# Altium schematic sheet MTIBv5_Top.SchDoc
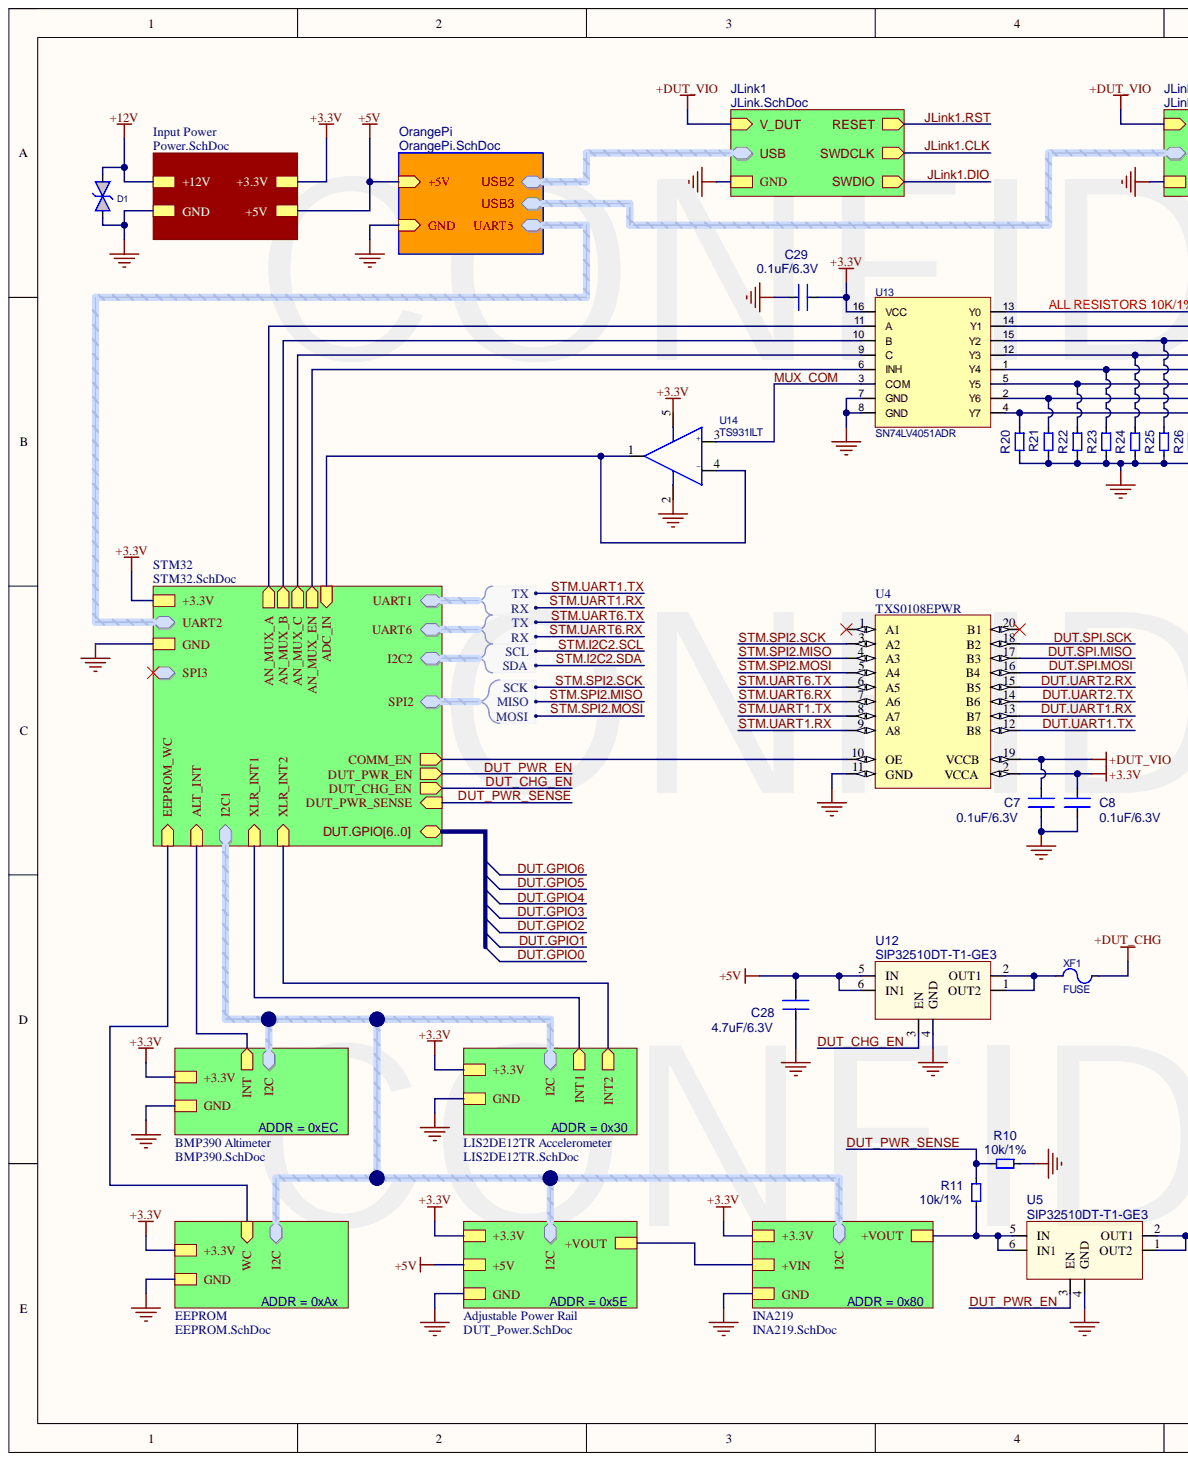
[diagram p0: MTIBv5_Top.SchDoc, left]
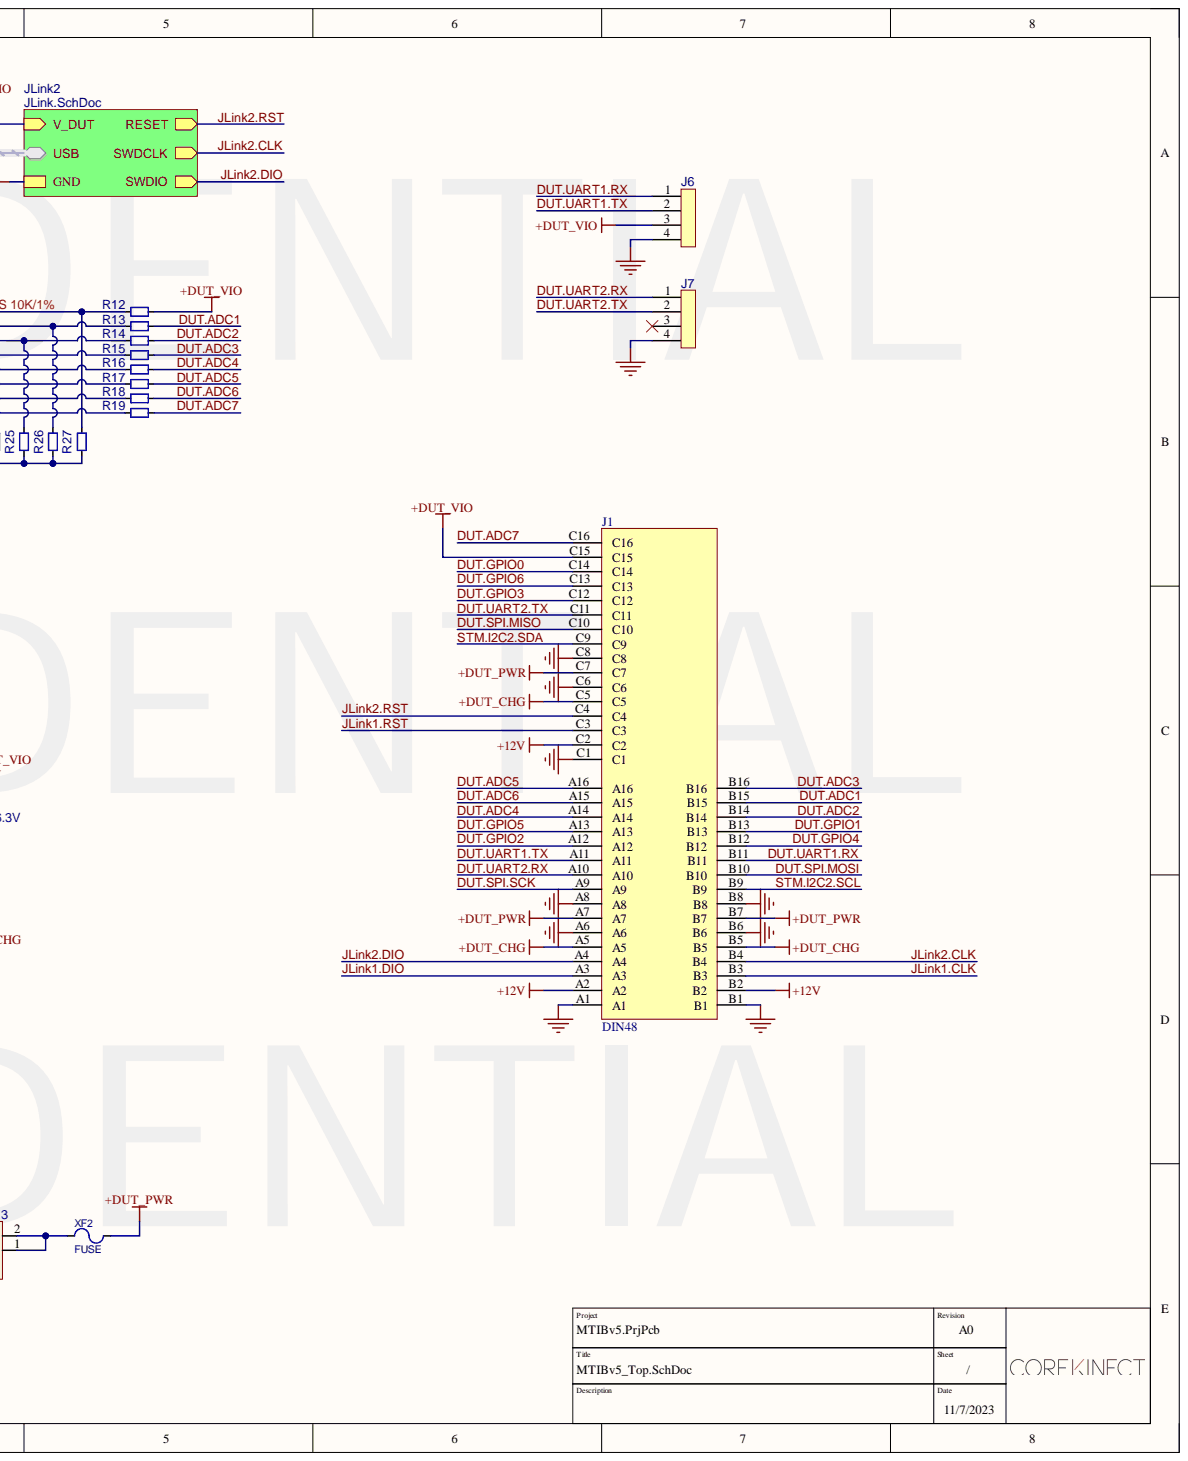
[diagram p1: MTIBv5_Top.SchDoc, right]

## Parts
C7  0.1uF/6.3V  [CAP_0402]
C8  0.1uF/6.3V  [CAP_0402]
C28  4.7uF/6.3V  [CAP_0402]
C29  0.1uF/6.3V  [CAP_0402]
D1  DIODE_TVS_BIDIRECTIONAL  [DO-214AC]  (value not drawn)
J1  DIN48  [86094327313755ELF]
J6  CONN_4Pin_1x4  [Molex_0530470410]  (value not drawn)
J7  CONN_4Pin_1x4  [Molex_0530470410]  (value not drawn)
R10  10k/1%  [RES_0402]
R11  10k/1%  [RES_0402]
R12  10k/1%  [RES_0402]  (value not drawn)
R13  10k/1%  [RES_0402]  (value not drawn)
R14  10k/1%  [RES_0402]  (value not drawn)
R15  10k/1%  [RES_0402]  (value not drawn)
R16  10k/1%  [RES_0402]  (value not drawn)
R17  10k/1%  [RES_0402]  (value not drawn)
R18  10k/1%  [RES_0402]  (value not drawn)
R19  10k/1%  [RES_0402]  (value not drawn)
R20  10k/1%  [RES_0402]  (value not drawn)
R21  10k/1%  [RES_0402]  (value not drawn)
R22  10k/1%  [RES_0402]  (value not drawn)
R23  10k/1%  [RES_0402]  (value not drawn)
R24  10k/1%  [RES_0402]  (value not drawn)
R25  10k/1%  [RES_0402]  (value not drawn)
R26  10k/1%  [RES_0402]  (value not drawn)
R27  10k/1%  [RES_0402]  (value not drawn)
U4  TXS0108EPWR  [TSSOP20-4.40mm]
U5  SIP32510DT-T1-GE3  [SOT-23-6]
U12  SIP32510DT-T1-GE3  [SOT-23-6]
U13  SN74LV4051ADR  [SOIC-16-150]
U14  TS931ILT  [SOT-23-5]
XF1  FUSE  [CAP_0603]
XF2  FUSE  [CAP_0603]

## Nets
+3.3V: C8.1 C29.2 U4.2(VCCA) U13.16(VCC) U14.5
+5V: C28.1 U12.5(IN) U12.6(IN1)
+12V: D1.1 J1.A2 J1.B2 J1.C2
+DUT_CHG: J1.A5 J1.B5 J1.C5 XF1.2
+DUT_PWR: J1.A7 J1.B7 J1.C7 XF2.2
+DUT_VIO: C7.1 J1.C15 J6.3 R12.1 U4.19(VCCB)
GND: C7.2 C8.2 C28.2 C29.1 D1.2 J1.A1 J1.A6 J1.A8 J1.B1 J1.B6 J1.B8 J1.C1 J1.C6 J1.C8 J6.4 J7.4 R10.2 R20.1 R21.1 R22.1 R23.1 R24.1 R25.1 R26.1 R27.1 U4.11(GND) U5.4(GND) U12.4(GND) U13.7(GND) U13.8(GND) U14.2
DUT.ADC1: J1.B15 R13.1
DUT.ADC2: J1.B14 R14.1
DUT.ADC3: J1.B16 R15.1
DUT.ADC4: J1.A14 R16.1
DUT.ADC5: J1.A16 R17.1
DUT.ADC6: J1.A15 R18.1
DUT.ADC7: J1.C16 R19.1
DUT.GPIO0: J1.C14  [also labelled DUT.GPIO]
DUT.GPIO1: J1.B13  [also labelled DUT.GPIO]
DUT.GPIO2: J1.A12  [also labelled DUT.GPIO]
DUT.GPIO3: J1.C12  [also labelled DUT.GPIO]
DUT.GPIO4: J1.B12  [also labelled DUT.GPIO]
DUT.GPIO5: J1.A13  [also labelled DUT.GPIO]
DUT.GPIO6: J1.C13  [also labelled DUT.GPIO]
DUT.SPI.MISO: J1.C10 U4.17(B3)
DUT.SPI.MOSI: J1.B10 U4.16(B4)
DUT.SPI.SCK: J1.A9 U4.18(B2)
DUT.UART1.RX: J1.B11 J6.1 U4.13(B7)
DUT.UART1.TX: J1.A11 J6.2 U4.12(B8)
DUT.UART2.RX: J1.A10 J7.1 U4.15(B5)
DUT.UART2.TX: J1.C11 J7.2 U4.14(B6)
DUT_CHG_EN: U12.3(EN)
DUT_PWR_EN: U5.3(EN)
DUT_PWR_SENSE: R10.1 R11.1
JLink1.CLK: J1.B3
JLink1.DIO: J1.A3
JLink1.RST: J1.C3
JLink2.CLK: J1.B4
JLink2.DIO: J1.A4
JLink2.RST: J1.C4
MUX_COM: U13.3(COM) U14.3(+)
STM.I2C2.SCL: J1.B9
STM.I2C2.SDA: J1.C9
STM.SPI2.MISO: U4.4(A3)
STM.SPI2.MOSI: U4.5(A4)
STM.SPI2.SCK: U4.3(A2)
STM.UART1.RX: U4.9(A8)
STM.UART1.TX: U4.8(A7)
STM.UART6.RX: U4.7(A6)
STM.UART6.TX: U4.6(A5)
NetJ7_3: J7.3
NetR11_2: R11.2 U5.5(IN) U5.6(IN1)
NetR12_2: R12.2 R27.2 U13.13(Y0)
NetR13_2: R13.2 R26.2 U13.14(Y1)
NetR14_2: R14.2 R25.2 U13.15(Y2)
NetR15_2: R15.2 R24.2 U13.12(Y3)
NetR16_2: R16.2 R23.2 U13.1(Y4)
NetR17_2: R17.2 R22.2 U13.5(Y5)
NetR18_2: R18.2 R21.2 U13.2(Y6)
NetR19_2: R19.2 R20.2 U13.4(Y7)
NetU4_1: U4.1(A1)
NetU4_10: U4.10(OE)
NetU4_20: U4.20(B1)
NetU5_1: U5.1(OUT2) U5.2(OUT1) XF2.1
NetU12_1: U12.1(OUT2) U12.2(OUT1) XF1.1
NetU13_6: U13.6(INH)
NetU13_9: U13.9(C)
NetU13_10: U13.10(B)
NetU13_11: U13.11(A)
NetU14_1: U14.1 U14.4
+VIN:
ALT_INT:
EEPROM_WC:
I2C1.SCL:
I2C1.SDA:
INT1:
INT2:
SPI3.MISO:
SPI3.MOSI:
SPI3.SCK:
UART2.RX:
UART2.TX:
USB2.DN:
USB2.DP:
USB3.DN:
USB3.DP:

## Library data of the parts
C7 C8 C29 = CAP-000006: 0.1uF, 100nF, 6.3V, X5R, 0402; Manufacturer 1: Samsung; Manufacturer Part Number 1: CL05A104KA5NNNC; Database Table Name: Capacitors; Component Kind: Standard; CPN: CAP-000006; Library Name: CoreKinectDatabaseLibrary.DbLib
C28 = CAP-00000B: 4.7uF, 6.3V, X5R, 0402; Manufacturer 1: Murata; Manufacturer Part Number 1: GRM155R60J475ME47D; Database Table Name: Capacitors; Component Kind: Standard; CPN: CAP-00000B; Library Name: CoreKinectDatabaseLibrary.DbLib
D1 = DIODE-000008: TVS DIODE 12VWM 19.9VC SMA; Manufacturer 1: Diodes Incorporated; Manufacturer Part Number 1: SMAJ12CA-13-F; Database Table Name: Diodes; Component Kind: Standard; CPN: DIODE-000008; Library Name: CoreKinectDatabaseLibrary.DbLib
J1 = CONN-000024: THT, DIN48, C/2, male, right angle; Manufacturer 1: Amphenol ICC (FCI); Manufacturer Part Number 1: 86094327313755E1LF; Database Table Name: Connectors; Component Kind: Standard; CPN: CONN-000024; Library Name: CoreKinectDatabaseLibrary.DbLib
J6 J7 = CONN-00001C: THT, 4 position, male, vertical; Manufacturer 1: Molex; Manufacturer Part Number 1: 53047-0410; Database Table Name: Connectors; Component Kind: Standard; CPN: CONN-00001C; Library Name: CoreKinectDatabaseLibrary.DbLib
R10-R27 = RES-000007: 10kOhm, 1%, 0402; Manufacturer 1: Samsung; Manufacturer Part Number 1: RC1005F103CS; Database Table Name: Resistors; Component Kind: Standard; CPN: RES-000007; Library Name: CoreKinectDatabaseLibrary.DbLib
U4 = IC-000018: Voltage translator, Bidirectional, 8-Ch; Manufacturer 1: Texas Instruments; Database Table Name: ICs; Component Kind: Standard; CPN: IC-000018; Manufacturer Part Number 1: TXS0108EPWR; Library Name: CoreKinectDatabaseLibrary.DbLib
U5 U12 = SW-000005: Load switch; Manufacturer 1: Vishay; Database Table Name: Switches; Component Kind: Standard; CPN: SW-000005; Manufacturer Part Number 1: SIP32510DT-T1-GE3; Library Name: CoreKinectDatabaseLibrary.DbLib
U13 = IC-000015: Analog MUX 8:1; Manufacturer 1: Texas Instruments; Database Table Name: ICs; Component Kind: Standard; CPN: IC-000015; Manufacturer Part Number 1: SN74LV4051ADR; Library Name: CoreKinectDatabaseLibrary.DbLib
U14 = SEMI-000008: Operational Amplifier 1 Circuit Rail-to-Rail; Manufacturer 1: STMicroelectronics; Database Table Name: Semiconductors; Component Kind: Standard; CPN: SEMI-000008; Manufacturer Part Number 1: TS931ILT; Library Name: CoreKinectDatabaseLibrary.DbLib
XF1 XF2 = FUSE_000001: FUSE BRD MNT 2A 32VAC 63VDC 0603; Manufacturer 1: Bel Fuse Inc.; Manufacturer Part Number 1: C2Q 2; Database Table Name: Fuses; Component Kind: Standard; CPN: FUSE_000001; Library Name: CoreKinectDatabaseLibrary.DbLib
